# Altium schematic sheet MIPI_Interface.SchDoc(MIPI_Interface)
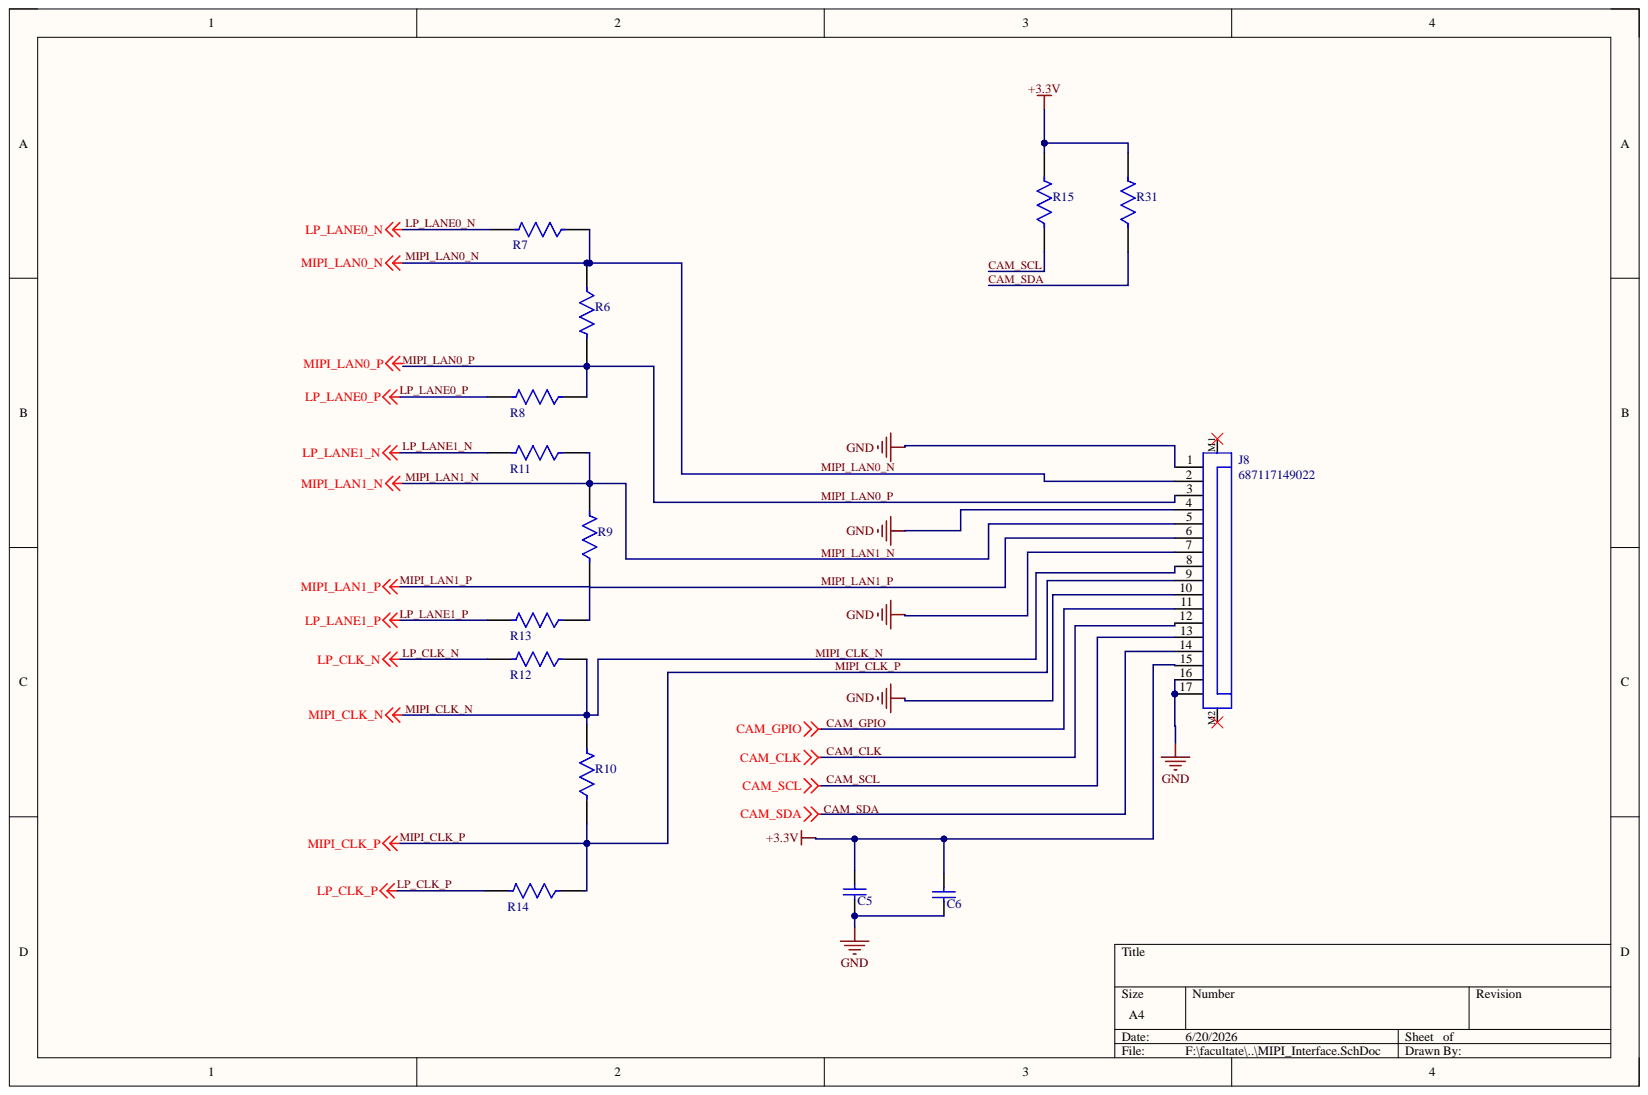
[diagram p0: MIPI_Interface.SchDoc]

## Parts
C5  VJ0805Y152KXAAC  [CAPC2013X145X48NL20T23]  (value not drawn)
C6  VJ0805Y152KXAAC  [CAPC2013X145X48NL20T23]  (value not drawn)
J8  687117149022  [687117149022]
R6  MCU0805MD4991BP100  [FP-MCU0805-MFG]  (value not drawn)
R7  MCU0805MD4991BP100  [FP-MCU0805-MFG]  (value not drawn)
R8  MCU0805MD4991BP100  [FP-MCU0805-MFG]  (value not drawn)
R9  MCU0805MD4991BP100  [FP-MCU0805-MFG]  (value not drawn)
R10  MCU0805MD4991BP100  [FP-MCU0805-MFG]  (value not drawn)
R11  MCU0805MD4991BP100  [FP-MCU0805-MFG]  (value not drawn)
R12  MCU0805MD4991BP100  [FP-MCU0805-MFG]  (value not drawn)
R13  MCU0805MD4991BP100  [FP-MCU0805-MFG]  (value not drawn)
R14  MCU0805MD4991BP100  [FP-MCU0805-MFG]  (value not drawn)
R15  MCU0805MD4991BP100  [FP-MCU0805-MFG]  (value not drawn)
R31  MCU0805MD4991BP100  [FP-MCU0805-MFG]  (value not drawn)

## Nets
+3.3V: C5.2 C6.2 J8.15 R15.2 R31.2
GND: C5.1 C6.1 J8.1 J8.4 J8.7 J8.10 J8.16 J8.17
CAM_CLK: J8.12
CAM_GPIO: J8.11
CAM_SCL: J8.13 R15.1
CAM_SDA: J8.14 R31.1
LP_CLK_N: R12.2
LP_CLK_P: R14.2
LP_LANE0_N: R7.2
LP_LANE0_P: R8.2
LP_LANE1_N: R11.2
LP_LANE1_P: R13.2
MIPI_CLK_N: J8.8 R10.2 R12.1
MIPI_CLK_P: J8.9 R10.1 R14.1
MIPI_LAN0_N: J8.2 R6.1 R7.1
MIPI_LAN0_P: J8.3 R6.2 R8.1
MIPI_LAN1_N: J8.5 R9.2 R11.1
MIPI_LAN1_P: J8.6 R9.1 R13.1

Not connected: J8 M1 M2

## Library data of the parts
C5 C6 = CMP-2007-01729-1: Manufacturer: Vitramon; Component Kind: Standard; Library Name: Altium Content Vault; Part Number: VJ0805Y152KXAAC
J8 = CMP-1502-01629-3: SMT ZIF Connectors Hinge Type WR-FPC, Horizontal, Bottom Contact, pitch 0.5 mm, 17 pins; Actuator Material: Nylon, Polyamide; Contact Resistance: 50mΩ; Current Rating: 500mA; Gender: Receptacle; Number of Contacts: 17; Operating Supply Voltage: 50V; Pitch: 508µm; Wire/Cable Type: Straight; Component Kind: Standard; Contact Material: Bronze; Contact Plating: Tin; Flammability Rating: UL94 V-0; Lead Pitch: 500µm; Library Name: Altium Content Vault; Max Operating Temperature: 105°C; Min Operating Temperature: -40°C; Mount: Surface Mount; Number of Pins: 17; Number of Positions: 17; Number of Rows: 1; Orientation: Horizontal; Packaging: Tape and Reel; REACH SVHC: Unknown; RoHS: Compliant; Termination: Solder; Voltage Rating: 50V
R6-R15 R31 = CMP-02407-000217-1: RES 1.05K OHM 0.5% 1/5W 0805; Power Rating: 200mW; Resistance: 4.99kR; Tolerance: 0.1%; Voltage Rating: 150V; Case Code (Imperial): 0805; Case Code (Metric): 2012; Case/Package: 0805; Component Kind: Standard; Composition: Thin Film; Height: 0.52mm; Length: 2mm; Library Name: Altium Content Vault; Max Operating Temperature: 155°C; Min Operating Temperature: -55°C; Package Quantity: 1000; Packaging: Tape and Reel; Termination: SMD/SMT; Weight: 0.000194oz; Width: 1.25mm
